# Altium schematic sheet ZTWL-DPKZQ-V2.1.SchDoc (continued)

## Library data of the parts
BUZ1 = 蜂鸣器-3V: Component Kind: Standard
C1 C01 C2 C02 C05 C10 C13 C15 C18 C26-C28 C37 C39 C47 C57 = Cap: Capacitor; value: 0.1uF/25V; 名称: 贴片电容; 封装: 0603; 特征描述: 0.1uF/25V、X7R、10%; 规格/型号: 0.1uF/25V、X7R、K; Component Kind: Standard; Library Name: Miscellaneous Devices.IntLib
C3 C03-C5 C06 C12 C19 C21-C24 C29-C36 C41-C46 C48-C56 C58-C61 = Cap: Capacitor; 名称: 贴片电容; 封装: 0603; 特征描述: 0.1uF/25V、X7R、10%; 规格/型号: 0.1uF/25V、X7R、K; Component Kind: Standard; Library Name: Miscellaneous Devices.IntLib
  C3 C03 C4 C06 C12 C19 C21-C24 C29-C36 C41-C46 C48-C56 C58-C61: value: 0.1uF/25V
C6 C8 C9 C14 C17 = Cap 0603: Capacitor 0603; value: 0.1UF; Component Kind: Standard; Library Name: Schlib1.SchLib
C7 = Cap 0603: Capacitor 0603; Component Kind: Standard; Library Name: Schlib1.SchLib
C11 C16 = Cap: Capacitor; Component Kind: Standard; LatestRevisionDate: 17-Jul-2002; LatestRevisionNote: Re-released for DXP Platform.; Library Name: Miscellaneous Devices.IntLib; PackageReference: RAD-0.3; Published: 8-Jun-2000; Signal Integrity: Cap; Simulation: CAP; Value: 0.1uF/25V; Publisher: Altium Limited
C20 = CAP1: Capacitor; Component Kind: Standard; Library Name: RC.SchLib
C38 C40 = RST47UF25V035: Component Kind: Standard
CN1 = KF2EDGR-5.08-12P: Manufacturer: KEFA(科发); Add into BOM: yes; Connector Type: 板端/插座-开口; Current Rating (Max): 15A; Number of PINs Per Row: 12; Pitch: 5.08mm; Structure: 1x12P; Voltage Rating (Max): 300V; Color: 绿色; Component Kind: Standard; Convert to PCB: yes; Datasheet: https://atta.szlcsc.com/upload/public/pdf/source/20230331/86255A6DF04E9E39F33DE3CFE8B3614A.pdf; Device: KF2EDGR-5.08-12P; JLCPCB Part Class: 扩展库; LCSC Part Name: 5.08mm 1x12P 排数:1 每排P数:12; Manufacturer Part: KF2EDGR-5.08-12P; Mounting Style: 弯针; Number of Pins: 12P; Number of Rows: 1; Operating Temperature Range: -40℃~+105℃; PCB3D: CONN-TH_12P-P5.08_KF2EDGR-5.08-12P; Supplier: LCSC; Supplier Footprint: 弯插,P=5.08mm; Supplier Part: C441202; Symbol: KF2EDGR-5.08-12P
D01 D18 D34 D38 = SS34F_C379829: Component Kind: Standard
D3 = PESDCAN1: Component Kind: Standard; Library Name: SCH.SCHLIB
D20 D22 D26 D29 D32 D36 = SMAJ12A-13-F: Component Kind: Standard; Library Name: ZTWL.schlib.SchLib
H1 = 6-146455-9: Component Kind: Standard
JP1 = PZ254V-11-08P: Component Kind: Standard
KEY1 = Self-locking switch 5.8ZWhite and white260G: Component Kind: Standard
L1 = MCS0630-2R2MPY1: Component Kind: Standard
L01 L2 = SMNR3015-100MT: Component Kind: Standard
MR1 MR2 = MARK: 光学定位点; 版权归属: 封装库来自淘宝 ID：黄晶宝石; BOM名称: 光学定位点; Component Kind: Standard; Library Name: 4.1 - 未分类器件.SchLib; 学习资源: https://shop463601444.taobao.com
P1 P2 = Header 3: Header, 3-Pin; Component Kind: Standard; LatestRevisionDate: 17-Jul-2002; LatestRevisionNote: Re-released for DXP Platform.; Library Name: Miscellaneous Connectors.IntLib; Signal Integrity: Connector; Publisher: Altium Limited
P4-P6 = Header 2: Header, 2-Pin; Component Kind: Standard; LatestRevisionDate: 17-Jul-2002; LatestRevisionNote: Re-released for DXP Platform.; Library Name: Miscellaneous Connectors.IntLib; Signal Integrity: Connector; Publisher: Altium Limited
Q3 = AO3400_C2848737: Component Kind: Standard
Q4 = AO3401_C2848197: Component Kind: Standard
R1 R3 R7 R9 R16 R31 R32 = Res2: Resistor; Component Kind: Standard; LatestRevisionDate: 17-Jul-2002; LatestRevisionNote: Re-released for DXP Platform.; Library Name: Miscellaneous Devices.IntLib; PackageReference: AXIAL-0.4; Published: 8-Jun-2000; Signal Integrity: Res; Simulation: RESISTOR; Publisher: Altium Limited
  R3 R9: Value: 10K
  R31 R32: PackageDescription: Axial Device, Thru-Hole; 2 Leads; 0.4 in Pin Spacing; Value: 10K
R01 = Res 0603: Resistor 0603; Value: 1003; Component Kind: Standard; Library Name: Schlib1.SchLib
R02 = Res 0603: Resistor 0603; Value: 5101; Component Kind: Standard; Library Name: Schlib1.SchLib
R03 R04 R6 R8 R10-R15 R17 R27-R29 R33-R44 R47-R49 = Res 0603: Resistor 0603; Component Kind: Standard
  R03 R13 R48: Library Name: Schlib1.SchLib
  R04 R8 R14 R27 R28 R47 R49: Library Name: Schlib1.SchLib; Value: 1K
  R6 R10-R12 R17: Library Name: Miscellaneous Devices.IntLib; Value: 10K
  R15 R33-R44: Library Name: Schlib1.SchLib; Value: 10K
  R29: Library Name: Miscellaneous Devices.IntLib; Value: 2K
R18 R19 = Res2: Resistor; Value: 4.7K; Component Kind: Standard; LatestRevisionDate: 17-Jul-2002; LatestRevisionNote: Re-released for DXP Platform.; PackageReference: AXIAL-0.4; Published: 8-Jun-2000; Publisher: Altium Limited
R20 R21 R24 R25 = Res2: Resistor; Value: 10K; Component Kind: Standard; LatestRevisionDate: 17-Jul-2002; LatestRevisionNote: Re-released for DXP Platform.; PackageReference: AXIAL-0.4; Published: 8-Jun-2000; Publisher: Altium Limited
R22 R23 = Res1: Resistor; Component Kind: Standard; Library Name: Miscellaneous Devices.IntLib
R26 = RES2: 贴片电阻; Component Kind: Standard
R30 R45 R46 = PA1206FRF470R008L: Component Kind: Standard
S1-S5 = 12*12*4.3轻触开关: Component Kind: Standard
U2 = KH-CR1220-2: Component Kind: Standard
U3 = BAT54C_C5184395: Component Kind: Standard
U6 = SP485EN-L/TR: Component Kind: Standard
U8 U10 U11 U13 U14 = rz7899: Component Kind: Standard; Library Name: ZTWL.schlib.SchLib
Y1 = SC-32S32.768kHz20PPM9pF: Component Kind: Standard
D2 D4-D17 = LED 0603: Component Kind: Standard; Description: LED 0603; Library Name: Schlib1.SchLib
D19 D21 D23-D25 D27 D28 D30 D31 D33 D35 D37 = SMAJ12A-13-F: Component Kind: Standard; Library Name: ZTWL.schlib.SchLib
U1 = TLV62569DBVR: Component Kind: Standard
U01 = MT3608: Component Kind: Standard
U4 = STM32F103RCT6: Component Kind: Standard
U5 = HT1621B: Component Kind: Standard
U7 = PT4056: Component Kind: Standard
U9 = rz7899: Component Kind: Standard; Library Name: ZTWL.schlib.SchLib
U12 = B6281R: Component Kind: Standard; Library Name: ZTWL.schlib.SchLib
